# Altium schematic sheet ICEPIC Cartridge for C64.SchDoc("ICEPIC Cartridge for C64")
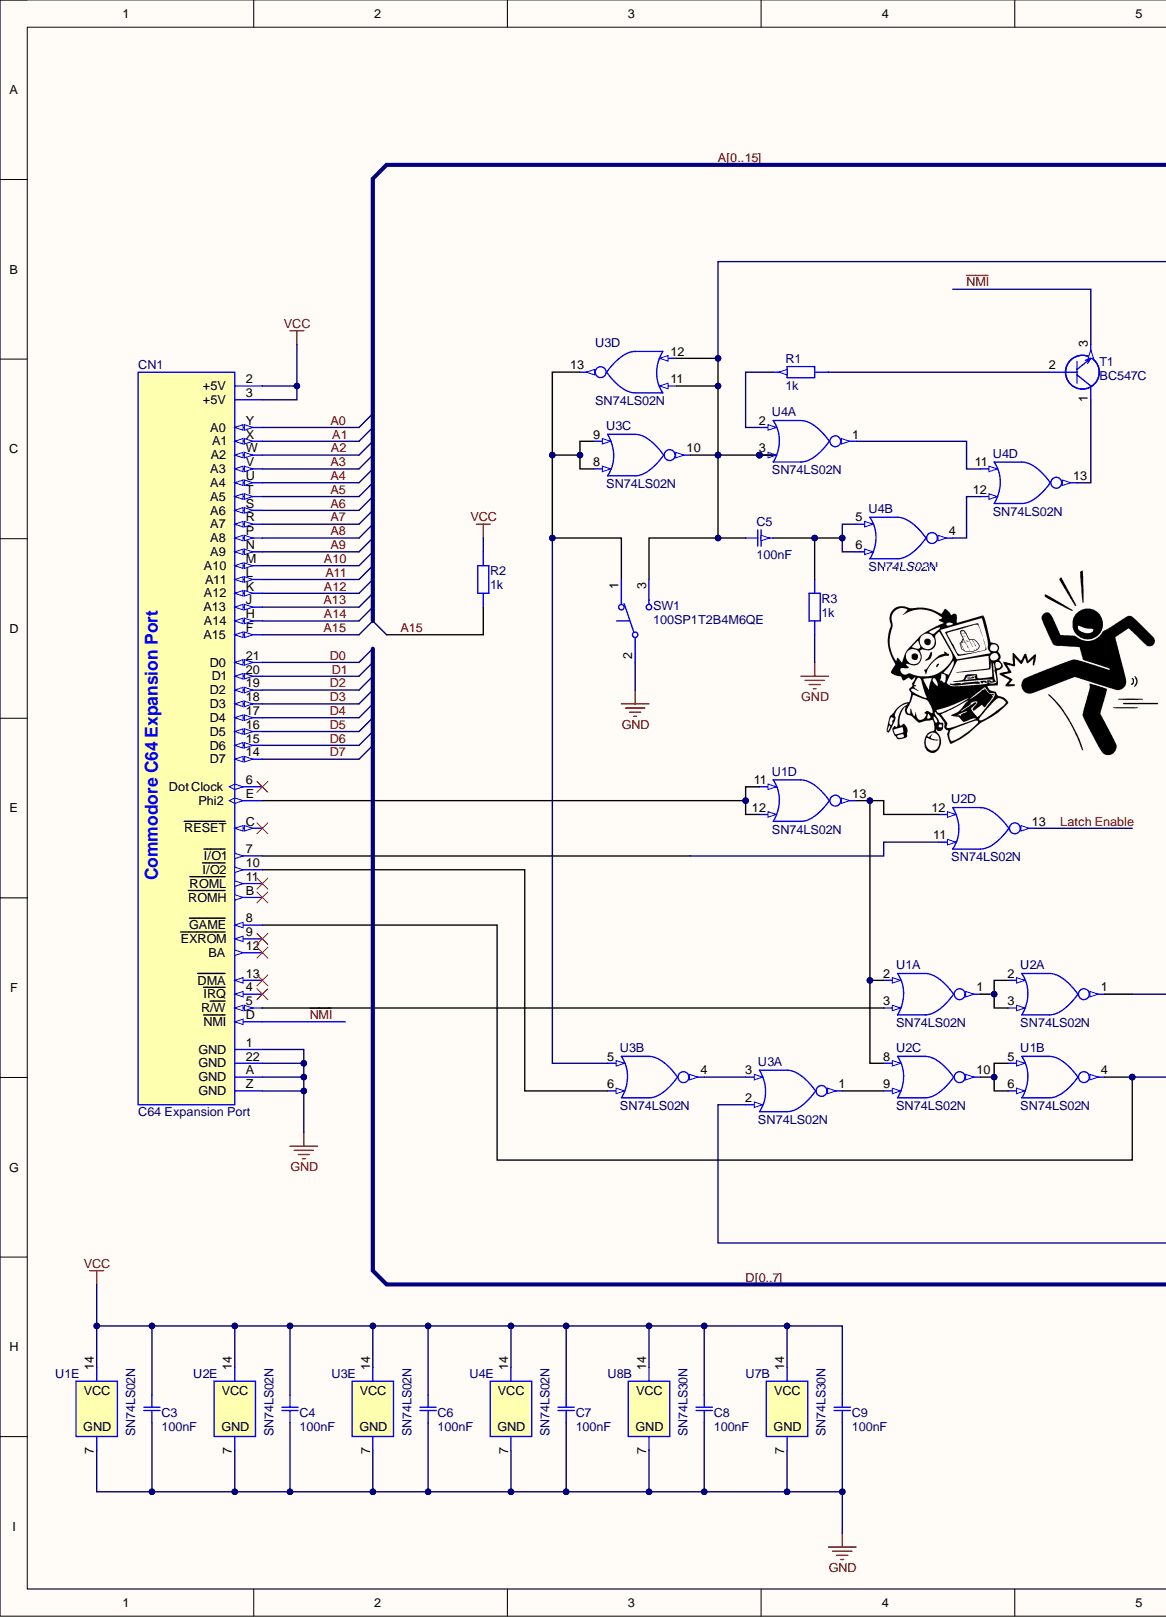
[diagram p0: ICEPIC Cartridge for C64.SchDoc, left]
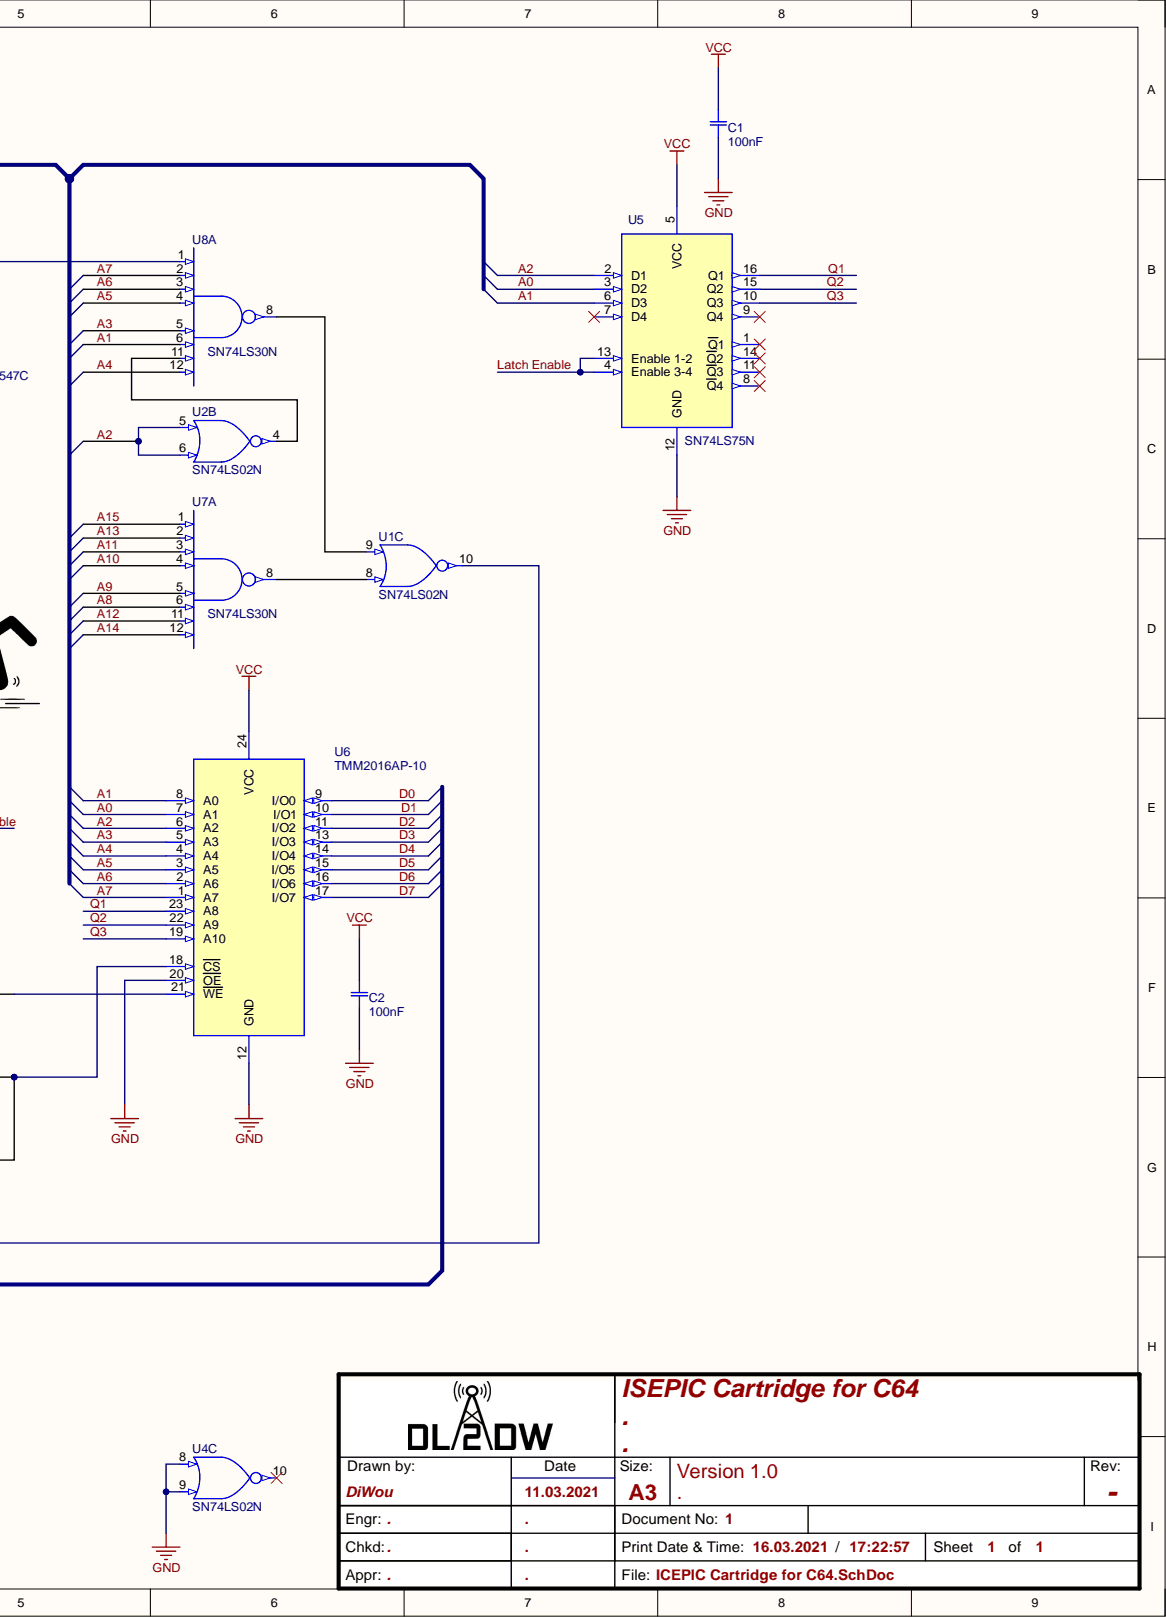
[diagram p1: ICEPIC Cartridge for C64.SchDoc, right]

## Parts
C1  100nF  [CAP Disc 100nF P2.54mm D5.5mm T3mm]
C2  100nF  [CAP Disc 100nF P2.54mm D5.5mm T3mm]
C3  100nF  [CAP Disc 100nF P2.54mm D5.5mm T3mm]
C4  100nF  [CAP Disc 100nF P2.54mm D5.5mm T3mm]
C5  100nF  [CAP Disc 100nF P2.54mm D5.5mm T3mm]
C6  100nF  [CAP Disc 100nF P2.54mm D5.5mm T3mm]
C7  100nF  [CAP Disc 100nF P2.54mm D5.5mm T3mm]
C8  100nF  [CAP Disc 100nF P2.54mm D5.5mm T3mm]
C9  100nF  [CAP Disc 100nF P2.54mm D5.5mm T3mm]
CN1  C64 Expansion Port  [C64 Expansion Port EDGE Connector]  (no BOM)
R1  1k  [1,0 kOhm R Axial DIN0207 L6.3mm D2.5mm P7.62mm Horizontal]
R2  1k  [1,0 kOhm R Axial DIN0207 L6.3mm D2.5mm P7.62mm Horizontal]
R3  1k  [1,0 kOhm R Axial DIN0207 L6.3mm D2.5mm P7.62mm Horizontal]
SW1  100SP1T2B4M6QE  [Toogle Switch SPDT 100SP1T2B4M6QE]
T1  BC547C  [BC547C]
U1  SN74LS02N  [SN74LS02N with Socket]  (parts A,B,C,D,E)
U2  SN74LS02N  [SN74LS02N with Socket]  (parts A,B,C,D,E)
U3  SN74LS02N  [SN74LS02N with Socket]  (parts A,B,C,D,E)
U4  SN74LS02N  [SN74LS02N with Socket]  (parts A,B,C,D,E)
U5  SN74LS75N  [SN74LS75N with Socket]
U6  TMM2016AP-10  [Toshiba TMM2016AP-10 - with Socket]
U7  SN74LS30N  [SN74LS30N with Socket]  (parts A,B)
U8  SN74LS30N  [SN74LS30N with Socket]  (parts A,B)

## Nets
GND: C1.1 C2.1 C3.1 C4.1 C6.1 C7.1 C8.1 C9.1 CN1.1(GND) CN1.22(GND) CN1.A(GND) CN1.Z(GND) R3.1 SW1.2 U1.7 U2.7 U3.7 U4.7 U4.8 U4.9 U5.12(GND) U6.12(GND) U6.20(OE) U7.7 U8.7
VCC: C1.2 C2.2 C3.2 C4.2 C6.2 C7.2 C8.2 C9.2 CN1.2(+5V) CN1.3(+5V) R2.2 U1.14 U2.14 U3.14 U4.14 U5.5(VCC) U6.24(VCC) U7.14 U8.14
A0: CN1.Y(A0) U5.3(D2) U6.7(A1)  [also labelled A]
A1: CN1.X(A1) U5.6(D3) U6.8(A0) U8.6  [also labelled A]
A2: CN1.W(A2) U2.5 U2.6 U5.2(D1) U6.6(A2)  [also labelled A]
A3: CN1.V(A3) U6.5(A3) U8.5  [also labelled A]
A4: CN1.U(A4) U6.4(A4) U8.12  [also labelled A]
A5: CN1.T(A5) U6.3(A5) U8.4  [also labelled A]
A6: CN1.S(A6) U6.2(A6) U8.3  [also labelled A]
A7: CN1.R(A7) U6.1(A7) U8.2  [also labelled A]
A8: CN1.P(A8) U7.6  [also labelled A]
A9: CN1.N(A9) U7.5  [also labelled A]
A10: CN1.M(A10) U7.4  [also labelled A]
A11: CN1.L(A11) U7.3  [also labelled A]
A12: CN1.K(A12) U7.11  [also labelled A]
A13: CN1.J(A13) U7.2  [also labelled A]
A14: CN1.H(A14) U7.12  [also labelled A]
A15: CN1.F(A15) R2.1 U7.1  [also labelled A]
D0: CN1.21(D0) U6.9(I/O0)  [also labelled D]
D1: CN1.20(D1) U6.10(I/O1)  [also labelled D]
D2: CN1.19(D2) U6.11(I/O2)  [also labelled D]
D3: CN1.18(D3) U6.13(I/O3)  [also labelled D]
D4: CN1.17(D4) U6.14(I/O4)  [also labelled D]
D5: CN1.16(D5) U6.15(I/O5)  [also labelled D]
D6: CN1.15(D6) U6.16(I/O6)  [also labelled D]
D7: CN1.14(D7) U6.17(I/O7)  [also labelled D]
Latch Enable: U2.13 U5.4 U5.13
~{NMI}: CN1.D(NMI) T1.3
Q1: U5.16(Q1) U6.23(A8)
Q2: U5.15(Q2) U6.22(A9)
Q3: U5.10(Q3) U6.19(A10)
NetC5_1: C5.1 SW1.3 U3.10 U3.11 U3.12 U4.3 U8.1
NetC5_2: C5.2 R3.2 U4.5 U4.6
NetCN1_5: CN1.5(R/W) U1.3
NetCN1_7: CN1.7(I/O1) U2.11
NetCN1_8: CN1.8(GAME) U1.4 U6.18(CS)
NetCN1_10: CN1.10(I/O2) U3.6
NetCN1_E: CN1.E(Phi2) U1.11 U1.12
NetR1_1: R1.1 T1.2
NetR1_2: R1.2 U4.2
NetSW1_1: SW1.1 U3.5 U3.8 U3.9 U3.13
NetT1_1: T1.1 U4.13
NetU1_1: U1.1 U2.2 U2.3
NetU1_2: U1.2 U1.13 U2.8 U2.12
NetU1_5: U1.5 U1.6 U2.10
NetU1_8: U1.8 U7.8
NetU1_9: U1.9 U8.8
NetU1_10: U1.10 U3.2
NetU2_1: U2.1 U6.21(WE)
NetU2_4: U2.4 U8.11
NetU2_9: U2.9 U3.1
NetU3_3: U3.3 U3.4
NetU4_1: U4.1 U4.11
NetU4_4: U4.4 U4.12

Not connected: CN1 4(IRQ) 6(Dot_Clock) 9(EXROM) 11(ROML) 12(BA) 13(DMA) B(ROMH) C(RESET); U4 10; U5 1(Q1) 7(D4) 8(Q4) 9(Q4) 11(Q3) 14(Q2)

## Library data of the parts
C1-C9 = CMP-Capacitors-Ceramic_Capacitors-00007-4: Ceramic Disc Capacitors 100nF -20%~+80% 50V Through Hole,P=2.54mm RoHS; Component Kind: Standard; ComponentLink1URL: https://lcsc.com/product-detail/Others_Dersonic-CC1H104ZA1PD3F5O3000_C353909.html; Library Name: PCB-Tech Cloud
CN1 = CMP-Commodore-00008-4: Expansion Port EDGE Connector for Interface Cards; Library Name: PCB-Tech Cloud
R1-R3 = CMP-Resistors-Yageo_THT_DIN_0207-00072-1: RES 1K OHM 1/4W 5% AXIAL; Max Power Dissipation: 250mW; Resistance: 1kR; Temperature Coefficient: 500ppm/°C; Case/Package: Axial; Component Kind: Standard; Composition: Carbon Film; Lead Free: Lead Free; Length: 0.248inch; Library Name: PCB-Tech Cloud; Max Operating Temperature: 155°C; Min Operating Temperature: -55°C; Number of Terminations: 2; Package Quantity: 200; Packaging: Bulk; Power Rating: 250mW; Tolerance: 5%
SW1 = CMP-Switches-00009-1: SWITCH TOGGLE SPDT 5A 120V; Actuator Color: Chrome; Actuator Length: 6.46mm; Actuator Material: Brass,Chrome; Actuator Type: Standard Round; Contact Resistance: 100mR; Electrical Life: 40000Cycles; Illumination Type: Non-Illuminated,Illuminated; Max Voltage Rating (AC): 250V; Max Voltage Rating (DC): 28V; Switch Function: On-On; Component Kind: Standard; Contact Material: Silver,Copper Alloy; Contact Plating: Silver; Current Rating: 5A; Housing Material: Stainless Steel; Lead Free: Lead Free; Length: 12.7mm; Library Name: PCB-Tech Cloud; Max Operating Temperature: 85°C; Min Operating Temperature: -30°C; Mount: Through Hole,Brackets; Orientation: Right Angle; Package Quantity: 1; Packaging: Bulk; RoHS Compliant: Yes; Series: 100; Termination: PC Pin; Throw Configuration: SPDT; Voltage Rating (AC): 120V; Voltage Rating (DC): 28V; Width: 6.86mm
T1 = CMP-Transistors-00002-1: BC547C Diotec Bipolar NPN Transistor 45V; Collector Base Voltage (VCBO): 50V; Collector Emitter Saturation Voltage: 600mV; Emitter Base Voltage (VEBO): 5V; Frequency: 300MHz; Number of Channels: 1; Power Dissipation: 500mW; Case/Package: TO-92; Collector Emitter Voltage (VCEO): 45V; Component Kind: Standard; Library Name: PCB-Tech Cloud; Max Operating Temperature: 150°C; Min Operating Temperature: -55°C; Mount: Through Hole; Number of Elements: 1; Number of Pins: 3; Packaging: Tape and Reel; Polarity: NPN
U1-U4 = CMP-ICs-74xx-series-00003-3: IC GATE NOR 4CH 2-INP 14DIP; High Level Output Current: -400uA; Low Level Output Current: 8mA; Max Supply Voltage: 5.25V; Min Supply Voltage: 4.75V; Number of Bits: 4; Number of Input Lines: 2; Number of Output Lines: 1; Operating Supply Voltage: 5V; Propagation Delay: 15ns; Quiescent Current: 2.8mA; Schmitt Trigger Input: No; Case/Package: PDIP; Component Kind: Standard; Contact Plating: Gold; Height: 4.57mm; Lead Free: Lead Free; Length: 19.3mm; Library Name: PCB-Tech Cloud; Logic Function: NOR; Max Operating Temperature: 70°C; Max Output Current: 8mA; Min Operating Temperature: 0°C; Mount: Through Hole; Number of Circuits: 4; Number of Elements: 4; Number of Gates: 4; Number of Inputs: 2; Number of Outputs: 1; Number of Pins: 14; Output Current: 8mA; Package Quantity: 25; Packaging: Rail/Tube; Radiation Hardening: No; REACH SVHC: No SVHC; Termination: Through Hole; Turn-On Delay Time: 15ns; Weight: 0.032734oz; Width: 6.35mm
U5 = CMP-ICs-74xx-series-00080-1: Latch Transparent 4-CH D-Type 16-Pin PDIP Tube; High Level Output Current: -400uA; Independent Circuits: 4; Logic Function: D-Type,Latch; Low Level Output Current: 8mA; Max Supply Voltage: 5.25V; Min Supply Voltage: 4.75V; Nominal Supply Current: 12mA; Number of Circuits: 2; Operating Supply Voltage: 5V; Polarity: Non-Inverting; Propagation Delay: 27ns; Quiescent Current: 6.3mA; Turn-On Delay Time: 9ns; Case/Package: PDIP; Component Kind: Standard; Contact Plating: Gold; Height: 4.57mm; Lead Free: Contains Lead; Length: 19.3mm; Library Name: PCB-Tech Cloud; Max Operating Temperature: 70°C; Min Operating Temperature: 0°C; Mount: Through Hole; Number of Bits: 4; Number of Elements: 2; Number of Input Lines: 4; Number of Output Lines: 4; Number of Pins: 16; Output Current: 8mA; Package Quantity: 25; Packaging: Rail/Tube; Radiation Hardening: No; REACH SVHC: No SVHC; Weight: 0.03357oz; Width: 6.35mm
U6 = CMP-ICs-Memory-00000-4: Integrated Circuit Memory 2016/TMM2016AP10 TOSHIBA Static RAM memory prog. volatille KX8=2; Component Kind: Standard; Library Name: PCB-Tech Cloud
U7 U8 = CMP-ICs-74xx-series-00029-2: IC GATE NAND 1CH 8-INP 14DIP; High Level Output Current: -16mA; Low Level Output Current: 400uA; Max Output Current: 8mA; Max Supply Voltage: 5.25V; Min Supply Voltage: 4.75V; Nominal Supply Current: 100uA; Number of Bits: 1; Number of Input Lines: 8; Operating Supply Voltage: 5V; Propagation Delay: 20ns; Turn-On Delay Time: 22ns; Case/Package: PDIP; Component Kind: Standard; Contact Plating: Gold; Lead Free: Lead Free; Library Name: PCB-Tech Cloud; Logic Function: NAND; Max Operating Temperature: 70°C; Min Operating Temperature: 0°C; Mount: Through Hole; Number of Circuits: 1; Number of Elements: 1; Number of Gates: 1; Number of Inputs: 8; Number of Output Lines: 1; Number of Outputs: 1; Number of Pins: 14; Output Current: 8mA; Package Quantity: 25; Packaging: Rail/Tube; Radiation Hardening: No; REACH SVHC: No SVHC; Termination: Through Hole; Weight: 0.032734oz
